# Altium schematic sheet srcFront.SchDoc
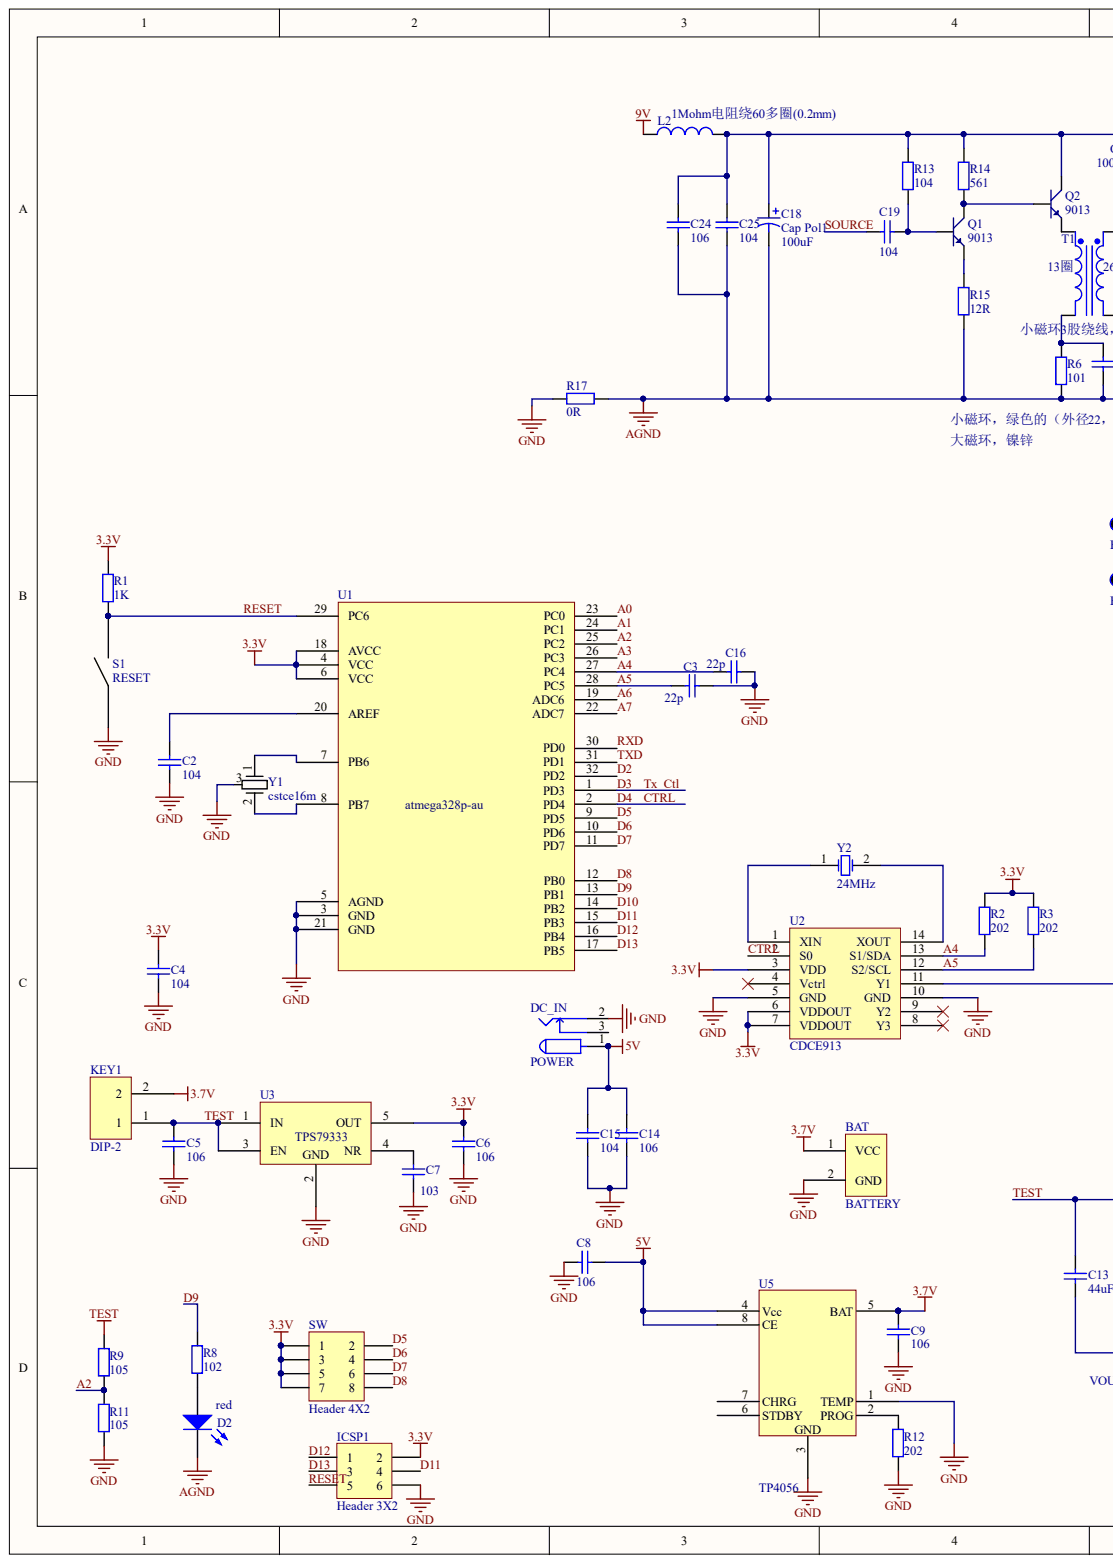
[diagram p0: srcFront.SchDoc, left]
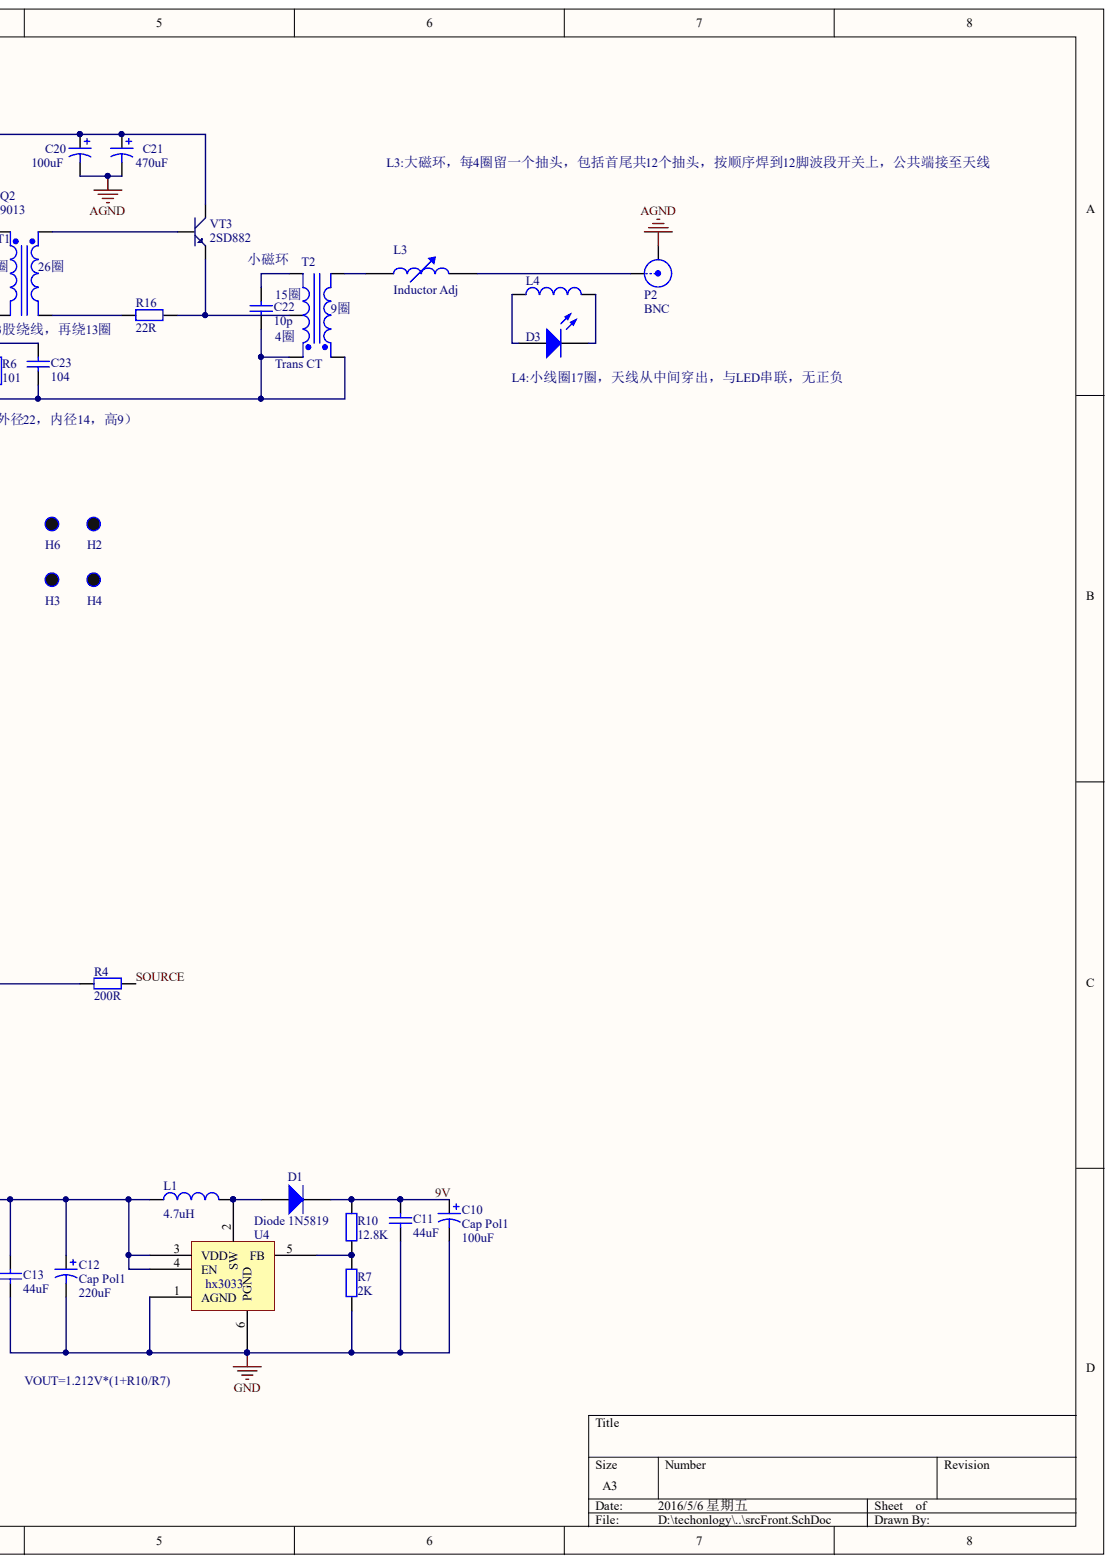
[diagram p1: srcFront.SchDoc, right]

## Parts
BAT  BATTERY  [DIP-2]
C2  value 104  [6-0805_N]  (hidden comment: Cap)
C3  value 22p  [6-0805_N]  (hidden comment: Cap)
C4  value 104  [6-0805_N]  (hidden comment: Cap)
C5  value 106  [6-0805_N]  (hidden comment: Cap)
C6  value 106  [6-0805_N]  (hidden comment: Cap)
C7  value 103  [6-0805_N]  (hidden comment: Cap)
C8  value 106  [6-0805_N]  (hidden comment: Cap)
C9  value 106  [6-0805_N]  (hidden comment: Cap)
C10  Cap Pol1  value 100uF  [100uF]
C11  value 44uF  [100uF]  (hidden comment: Cap)
C12  Cap Pol1  value 220uF  [100uF]
C13  value 44uF  [100uF]  (hidden comment: Cap)
C14  value 106  [6-0805_N]  (hidden comment: Cap)
C15  value 104  [6-0805_N]  (hidden comment: Cap)
C16  value 22p  [6-0805_N]  (hidden comment: Cap)
C18  Cap Pol1  value 100uF  [100uF]
C19  value 104  [6-0805_N]  (hidden comment: Cap)
C20  value 100uF  [100uF]  (hidden comment: Cap Pol1)
C21  value 470uF  [100uF]  (hidden comment: Cap Pol1)
C22  value 10p  [6-0805_N]  (hidden comment: Cap)
C23  value 104  [6-0805_N]  (hidden comment: Cap)
C24  value 106  [6-0805_N]  (hidden comment: Cap)
C25  value 104  [6-0805_N]  (hidden comment: Cap)
D1  Diode 1N5819  [SMB]
D2  color: red  [LED_DIP]  (hidden comment: LED3)
D3  LED0  [LED-0]  (value not drawn)
DC_IN  POWER  [DC10B]
H2  HOLE  [HOLE_3.0]  (value not drawn)
H3  HOLE  [HOLE_3.0]  (value not drawn)
H4  HOLE  [HOLE_3.0]  (value not drawn)
H6  HOLE  [HOLE_3.0]  (value not drawn)
ICSP1  Header 3X2  [HDR2X3]
KEY1  DIP-2  [HDR1X2]
L1  Inductor  value 4.7uH  [GDRH127]
L2  Inductor  value 1Mohm电阻绕60多圈(0.2mm)  [AXIAL-0.4]
L3  Inductor Adj  [AXIAL-0.8]
L4  Inductor  [0402-A]
P2  BNC  [SMB_V-RJ45]
Q1  9013  [SOT-23R]
Q2  9013  [SOT-23R]
R1  value 1K  [6-0805_N]  (hidden comment: Res2)
R2  value 202  [6-0805_N]  (hidden comment: Res2)
R3  value 202  [6-0805_N]  (hidden comment: Res2)
R4  value 200R  [6-0805_N]  (hidden comment: Res2)
R6  value 101  [6-0805_N]  (hidden comment: Res2)
R7  value 2K  [6-0805_N]  (hidden comment: Res2)
R8  value 102  [6-0805_N]  (hidden comment: Res2)
R9  value 105  [6-0805_N]  (hidden comment: Res2)
R10  value 12.8K  [6-0805_N]  (hidden comment: Res2)
R11  value 105  [6-0805_N]  (hidden comment: Res2)
R12  value 202  [6-0805_N]  (hidden comment: Res2)
R13  value 104  [6-0805_N]  (hidden comment: Res2)
R14  value 561  [6-0805_N]  (hidden comment: Res2)
R15  value 12R  [6-0805_N]  (hidden comment: Res2)
R16  value 22R  [6-0805_N]  (hidden comment: Res2)
R17  value 0R  [6-0805_N]  (hidden comment: Res2)
S1  RESET  [KEY]
SW  Header 4X2  [HDR2X4]
T1  小磁环3股绕线，再绕13圈  [4pin]
T2  Trans CT  [5pin]
U1  atmega328p-au  [TQFP-32N-1]
U2  CDCE913  [CDCD913]
U3  TPS79333  [SOT-23-5]
U4  hx3033  [HX3033]
U5  TP4056  [SOP-PP-8]
VT3  2SD882  [D882]
Y1  cstce16m  [cstce8m]
Y2  24MHz  [XTAL_US]

## Nets
3.3V: C4.2 C6.2 ICSP1.2 R1.2 R2.2 R3.2 SW.1 SW.3 SW.5 SW.7 U1.4(VCC) U1.6(VCC) U1.18(AVCC) U2.3(VDD) U2.6(VDDOUT) U2.7(VDDOUT) U3.5(OUT)
3.7V: BAT.1 C9.2 KEY1.2 U5.5(BAT)
5V: C8.2 C14.1 C15.1 DC_IN.1 U5.4(Vcc) U5.8(CE)
AGND: C18.2 C20.2 C21.2 C22.1 C23.1 C24.2 C25.2 D2.2 P2.2 R6.1 R15.1 R17.1 T2.1 T2.3
GND: BAT.2 C2.1 C3.2 C4.1 C5.1 C6.1 C7.1 C8.1 C9.1 C10.2 C11.1 C12.2 C13.1 C14.2 C15.2 C16.2 DC_IN.2 ICSP1.6 R7.2 R11.2 R12.1 R17.2 S1.1 U1.3(GND) U1.5(AGND) U1.21(GND) U2.5(GND) U2.10(GND) U3.2 U4.1(AGND) U4.6(PGND) U5.1(TEMP) U5.3 Y1.3
9V: C10.1 C11.2 D1.2 L2.1 R10.1
A0: U1.23(PC0)
A1: U1.24(PC1)
A2: R9.2 R11.1 U1.25(PC2)
A3: U1.26(PC3)
A4: C16.1 R2.1 U1.27(PC4) U2.13(S1/SDA)
A5: C3.1 R3.1 U1.28(PC5) U2.12(S2/SCL)
A6: U1.19(ADC6)
A7: U1.22(ADC7)
CTRL: U1.2(PD4) U2.2(S0)
D2: U1.32(PD2)
D3: U1.1(PD3)
D5: SW.2 U1.9(PD5)
D6: SW.4 U1.10(PD6)
D7: SW.6 U1.11(PD7)
D8: SW.8 U1.12(PB0)
D9: R8.2 U1.13(PB1)
D10: U1.14(PB2)
D11: ICSP1.4 U1.15(PB3)
D12: ICSP1.1 U1.16(PB4)
D13: ICSP1.3 U1.17(PB5)
RESET: ICSP1.5 R1.1 S1.2 U1.29(PC6)
RXD: U1.30(PD0)
SOURCE: C19.2 R4.2
TEST: C5.2 C12.1 C13.2 KEY1.1 L1.1 R9.1 U3.1(IN) U3.3(EN) U4.3(VDD) U4.4(EN)
TXD: U1.31(PD1)
NetC2_2: C2.2 U1.20(AREF)
NetC7_2: C7.2 U3.4(NR)
NetC18_1: C18.1 C20.1 C21.1 C24.1 C25.1 L2.2 Q2.3 R13.2 R14.2 VT3.3
NetC19_1: C19.1 Q1.2 R13.1
NetC22_2: C22.2 T2.5
NetC23_2: C23.2 R6.2 T1.2
NetD1_1: D1.1 L1.2 U4.2(SW)
NetD2_1: D2.1 R8.1
NetD3_1: D3.1 L4.1
NetD3_2: D3.2 L4.2
NetL3_1: L3.1 T2.2
NetL3_2: L3.2 P2.1
NetQ1_1: Q1.1 R15.2
NetQ1_3: Q1.3 Q2.2 R14.1
NetQ2_1: Q2.1 T1.1
NetR4_1: R4.1 U2.11(Y1)
NetR7_1: R7.1 R10.2 U4.5(FB)
NetR12_2: R12.2 U5.2(PROG)
NetR16_1: R16.1 T2.4 VT3.1
NetR16_2: R16.2 T1.4
NetT1_3: T1.3 VT3.2
NetU1_7: U1.7(PB6) Y1.1
NetU1_8: U1.8(PB7) Y1.2
NetU2_1: U2.1(XIN) Y2.1
NetU2_14: U2.14(XOUT) Y2.2

Not connected: DC_IN 3; U2 4(Vctrl) 8(Y3) 9(Y2); U5 6(STDBY) 7(CHRG)

## Library data of the parts
C2-C9 C11 C13-C16 C19 C22-C25 = Cap: Capacitor; Component Kind: Standard; LatestRevisionDate: 17-Jul-2002; LatestRevisionNote: Re-released for DXP Platform.; PackageDescription: Radial Cap, Thru-Hole; 2 Leads; 0.3 in Pin Spacing; PackageReference: RAD-0.3; Published: 8-Jun-2000; Signal Integrity: Cap; Simulation: CAP; Publisher: Altium Limited
  C2-C9 C11 C13-C16: Library Name: zhukong.IntLib
  C19 C22-C25: Library Name: srcFront.IntLib
C10 C12 C18 C20 C21 = Cap Pol1: Polarized Capacitor (Radial); Component Kind: Standard; LatestRevisionDate: 17-Jul-2002; LatestRevisionNote: Re-released for DXP Platform.; PackageDescription: Polarized Capacitor (Radial); 2 Leads; PackageReference: RB7.6-15; Published: 8-Jun-2000; Signal Integrity: Cap Pol; Simulation: CAP; Library Name: dakaqi.IntLib; Publisher: Altium Limited
D1 = Diode 1N5408: 3 Amp Medium Power Silicon Rectifier Diode; Component Kind: Standard; ComponentLink1Description: Manufacturer Link; ComponentLink1URL: http://www.irf.com/; DatasheetVersion: Aug-1984; LatestRevisionDate: 17-Jul-2002; LatestRevisionNote: Re-released for DXP Platform.; Library Name: Miscellaneous Devices.IntLib; PackageDescription: Diode, Axial; Body 9.5 x 5.3 mm (LxDia. max), Lead Dia. 1.32 mm (max); PackageReference: DO-201AD; Published: 8-Jun-2000; Signal Integrity: 1N5408; Simulation: 1N5408; Publisher: Altium Limited
D2 = LED3: Typical BLUE SiC LED; Component Kind: Standard; DatasheetVersion: 16-Apr-2002; LatestRevisionDate: 17-Dec-2003; LatestRevisionNote: PCB Footprint replaced.; PackageDescription: SM LED; 2 C-Bend Leads; Body 3.5 x 2.8 x 1.9 mm, inc leads (LxWxH typ); PackageReference: 3.5X2.8X1.9; PackageVersion: 16-Apr-2002; Published: 8-Jun-2000; Signal Integrity: LED; Simulation: LED3; Library Name: zhukong.IntLib; Publisher: Altium Limited
D3 = LED0: Typical INFRARED GaAs LED; Component Kind: Standard; LatestRevisionDate: 08-Jul-2005; LatestRevisionNote: Mech Layer 1 removed.; Library Name: Miscellaneous Devices.IntLib; PackageDescription: LED; 2 Leads; PackageReference: LED-0; Published: 8-Jun-2000; Simulation: LED0; Publisher: Altium Limited
DC_IN = POW: Component Kind: Standard; Library Name: Explorer STM32F407封装库.IntLib
ICSP1 = Header 3X2: Header, 3-Pin, Dual row; Component Kind: Standard; LatestRevisionDate: 17-Jul-2002; LatestRevisionNote: Re-released for DXP Platform.; Signal Integrity: Connector; Library Name: zhukong.IntLib; Publisher: Altium Limited
L1 = Inductor: Code_IEC: 1005; Code_JEITA: 0402; Component Kind: Standard; Description: Inductor; LatestRevisionDate: 29-May-2009; LatestRevisionNote: IPC-7351 Footprint Added.; Library Name: Miscellaneous Devices.IntLib; PackageDescription: Chip Inductor; PackageReference: 0402-A; Published: 8-Jun-2000; Signal Integrity: Inductor; Simulation: INDUCTOR; Publisher: Altium Limited
L2 = Inductor: Code_IEC: 1005; Value: 1Mohm电阻绕60多圈(0.2mm); Code_JEITA: 0402; Component Kind: Standard; Description: Inductor; LatestRevisionDate: 29-May-2009; LatestRevisionNote: IPC-7351 Footprint Added.; Library Name: Miscellaneous Devices.IntLib; PackageDescription: Chip Inductor; PackageReference: 0402-A; Published: 8-Jun-2000; Signal Integrity: Inductor; Simulation: INDUCTOR; Publisher: Altium Limited
L3 = Inductor Adj: Adjustable Inductor; Set Position: 0.5; Component Kind: Standard; LatestRevisionDate: 17-Jul-2002; LatestRevisionNote: Re-released for DXP Platform.; Library Name: Miscellaneous Devices.IntLib; PackageDescription: Axial Device, Thru-Hole; 2 Leads; 0.8 in Pin Spacing; PackageReference: AXIAL-0.8; Published: 24-Mar-1999; Signal Integrity: Inductor Adj; Simulation: INDUCTOR; Publisher: Altium Limited
L4 = Inductor: Code_IEC: 1005; Value: 10mH; Code_JEITA: 0402; Component Kind: Standard; Description: Inductor; LatestRevisionDate: 29-May-2009; LatestRevisionNote: IPC-7351 Footprint Added.; Library Name: Miscellaneous Devices.IntLib; PackageDescription: Chip Inductor; PackageReference: 0402-A; Published: 8-Jun-2000; Signal Integrity: Inductor; Simulation: INDUCTOR; Publisher: Altium Limited
P2 = BNC: BNC Elbow Connector; Component Kind: Standard; LatestRevisionDate: 17-Jul-2002; LatestRevisionNote: Re-released for DXP Platform.; Signal Integrity: Connector; Library Name: srcFront.IntLib; Publisher: Altium Limited
Q1 Q2 VT3 = NPN: 8050/BCW846/BCW847; Component Kind: Standard
  Q1 Q2: Library Name: 战舰STM32开发板底板封装库.IntLib
  VT3: Library Name: srcFront.IntLib
R1-R4 R6-R17 = Res2: Resistor; Component Kind: Standard; LatestRevisionDate: 17-Jul-2002; LatestRevisionNote: Re-released for DXP Platform.; PackageDescription: Axial Device, Thru-Hole; 2 Leads; 0.4 in Pin Spacing; PackageReference: AXIAL-0.4; Published: 8-Jun-2000; Signal Integrity: Res; Simulation: RESISTOR; Publisher: Altium Limited
  R1-R4 R7-R12: Library Name: zhukong.IntLib
  R6 R13-R17: Library Name: srcFront.IntLib
S1 = KEY: Component Kind: Standard; Library Name: Schlib1.SchLib
SW = Header 4X2: Header, 4-Pin, Dual row; Component Kind: Standard; LatestRevisionDate: 17-Jul-2002; LatestRevisionNote: Re-released for DXP Platform.; Library Name: Miscellaneous Connectors.IntLib; Signal Integrity: Connector; Publisher: Altium Limited
T1 = Trans Eq: Transformer (Equivalent Circuit Model); Component Kind: Standard; LatestRevisionDate: 17-Jul-2002; LatestRevisionNote: Re-released for DXP Platform.; Library Name: Miscellaneous Devices.IntLib; PackageDescription: Transformer; 4 Leads; PackageReference: TRF_4; Published: 8-Jun-2000; Simulation: TRANS; Publisher: Altium Limited
T2 = Trans CT: Center-Tapped Transformer (Coupled Inductor Model); Component Kind: Standard; LatestRevisionDate: 17-Jul-2002; LatestRevisionNote: Re-released for DXP Platform.; Library Name: Miscellaneous Devices.IntLib; PackageDescription: Transformer; 5 Leads; PackageReference: TRF_5; Published: 8-Jun-2000; Simulation: TRANSFORMER; Publisher: Altium Limited
Y1 = cstce8m: Component Kind: Standard; Library Name: Schlib1.SchLib
Y2 = XTAL: Crystal Oscillator; Component Kind: Standard; LatestRevisionDate: 17-Jul-2002; LatestRevisionNote: Re-released for DXP Platform.; Library Name: Explorer STM32F407封装库.IntLib; Published: 8-Jun-2000; Simulation: XTAL; Publisher: Altium Limited
BAT = BATTERY: Component Kind: Standard; Library Name: Schlib1.SchLib
H2-H4 H6 = HOLE: Component Kind: Standard; Library Name: zhukong.IntLib
KEY1 = DIP-2: Component Kind: Standard; Library Name: zouwei.SCHLIB
U1 = atmega328p-au: Component Kind: Standard; Library Name: Schlib1.SchLib
U2 = CDCE913: Component Kind: Standard; Library Name: Schlib1.SchLib
U3 = TPS79333: Component Kind: Standard; Library Name: dakaqi.IntLib
U4 = hx3033: Component Kind: Standard; Library Name: Schlib1.SchLib
U5 = TP4056: Component Kind: Standard; Library Name: mp3_digital.SCHLIB
